# Altium schematic sheet pca20027 - rocketry.SchDoc
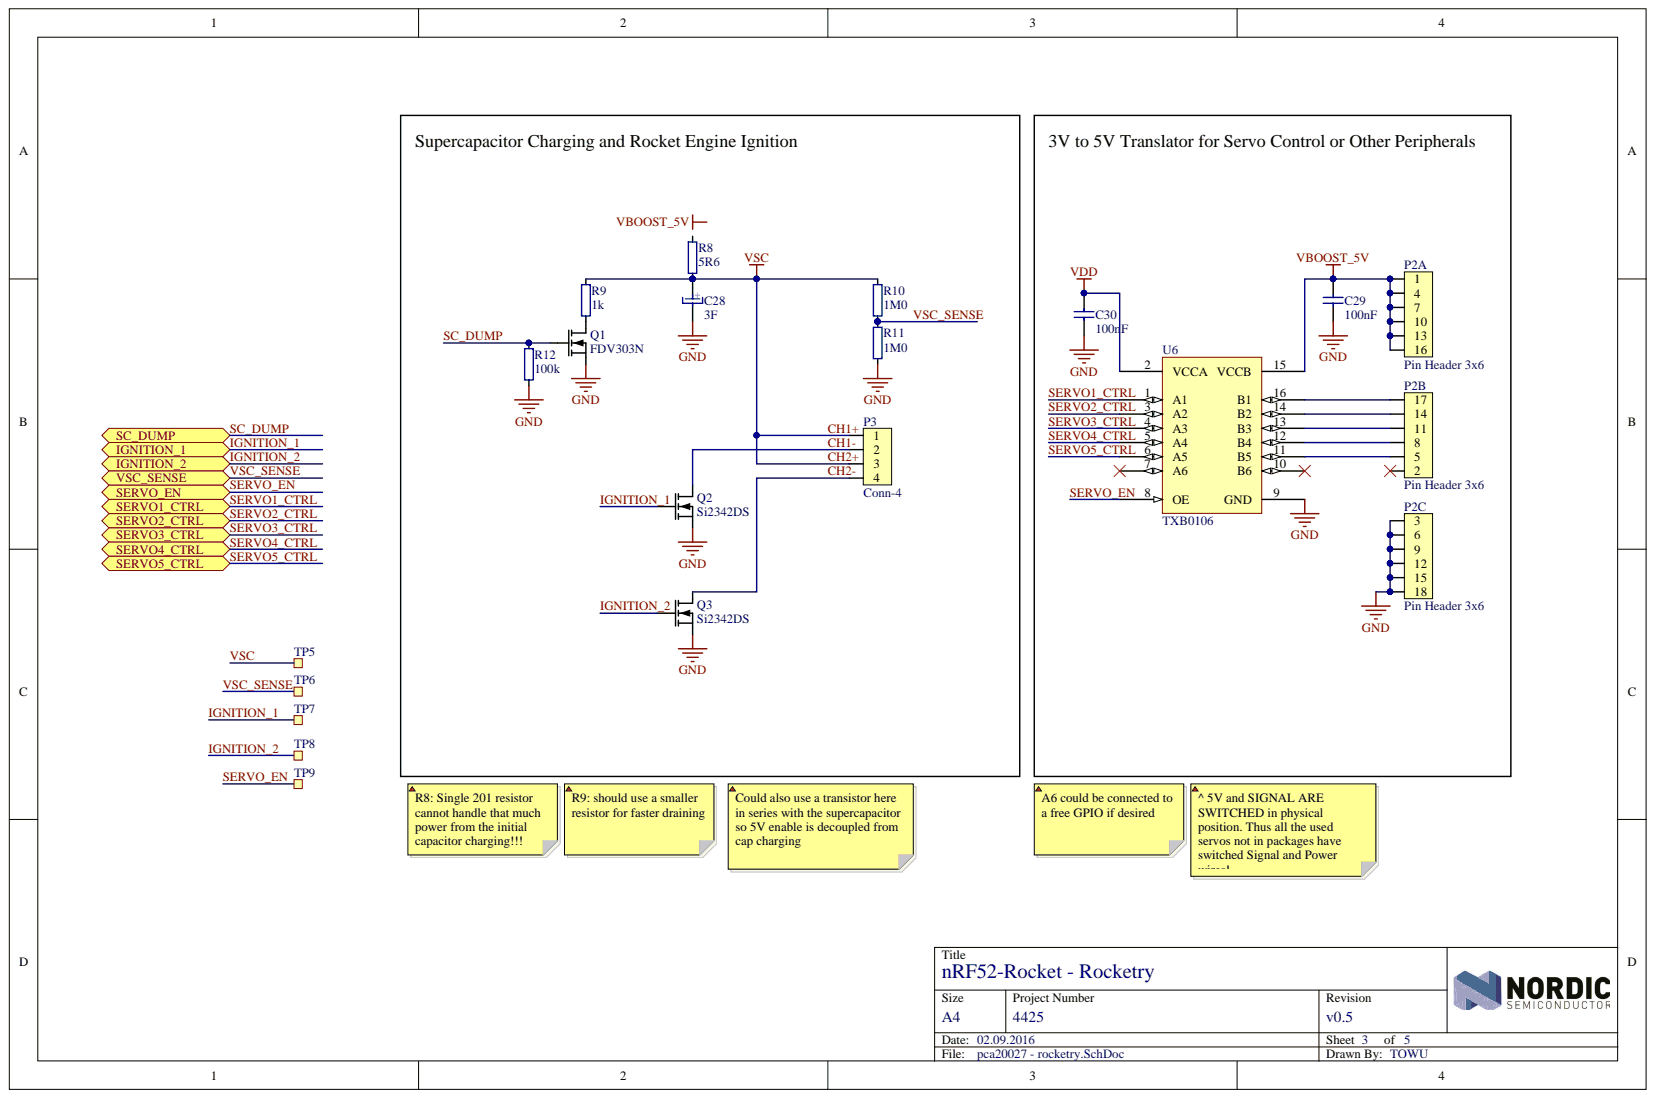
[diagram p0: pca20027 - rocketry.SchDoc]

## Parts
C28  3F  [PM-5R0H305-R]
C29  100nF  [CAPC1608X06L]
C30  100nF  [CAPC1608X06L]
P2  Pin Header 3x6  [HDR_3x6]  (parts A,B,C)
P3  Conn-4  [MPT 0,5/4-2,54]
Q1  FDV303N  [SOT-23a]
Q2  Si2342DS  [SOT-23a]
Q3  Si2342DS  [SOT-23a]
R8  5R6  [RESC1005X04L]
R9  1k  [RESC1608X06L]
R10  1M0  [RESC1608X06L]
R11  1M0  [RESC1608X06L]
R12  100k  [RESC1608X06L]
TP5  Ø1.0mm,SMD  [TP_SMD_Ø1.0mm]  (value not drawn)  (no BOM)
TP6  Ø1.0mm,SMD  [TP_SMD_Ø1.0mm]  (value not drawn)  (no BOM)
TP7  Ø1.0mm,SMD  [TP_SMD_Ø1.0mm]  (value not drawn)  (no BOM)
TP8  Ø1.0mm,SMD  [TP_SMD_Ø1.0mm]  (value not drawn)  (no BOM)
TP9  Ø1.0mm,SMD  [TP_SMD_Ø1.0mm]  (value not drawn)  (no BOM)
U6  TXB0106  [TSOP65P640X110-16N]

## Ports
IGNITION_1, IGNITION_2, SC_DUMP, SERVO1_CTRL, SERVO2_CTRL, SERVO3_CTRL, SERVO4_CTRL, SERVO5_CTRL, SERVO_EN, VSC_SENSE

## Nets
GND: C28.1 C29.1 C30.1 P2.3 P2.6 P2.9 P2.12 P2.15 P2.18 Q1.2 Q2.2 Q3.2 R11.1 R12.1 U6.9(GND)
VBOOST_5V: C29.2 P2.1 P2.4 P2.7 P2.10 P2.13 P2.16 U6.15(VCCB)
VDD: C30.2 U6.2(VCCA)
CH1+: C28.2 P3.1 P3.3 R8.1 R9.2 R10.2 TP5.1
CH1-: P3.2 Q2.3
CH2-: P3.4 Q3.3
IGNITION_1: Q2.1 TP7.1 ; port IGNITION_1
IGNITION_2: Q3.1 TP8.1 ; port IGNITION_2
SC_DUMP: Q1.1 R12.2 ; port SC_DUMP
SERVO1_CTRL: U6.1(A1) ; port SERVO1_CTRL
SERVO2_CTRL: U6.3(A2) ; port SERVO2_CTRL
SERVO3_CTRL: U6.4(A3) ; port SERVO3_CTRL
SERVO4_CTRL: U6.5(A4) ; port SERVO4_CTRL
SERVO5_CTRL: U6.6(A5) ; port SERVO5_CTRL
SERVO_EN: TP9.1 U6.8(OE) ; port SERVO_EN
VSC_SENSE: R10.1 R11.2 TP6.1 ; port VSC_SENSE
NetP2_5: P2.5 U6.11(B5)
NetP2_8: P2.8 U6.12(B4)
NetP2_11: P2.11 U6.13(B3)
NetP2_14: P2.14 U6.14(B2)
NetP2_17: P2.17 U6.16(B1)
NetQ1_3: Q1.3 R9.1

Not connected: P2 2; R8 2; U6 7(A6) 10(B6)

## Library data of the parts
C28 = PM-5R0H305-R: 3F, 5V Supercapacitor (EDLC); Manufacturer: Cooper Industries; Artikkelnr Noca: N.A.; Database Table Name: Capacitors$; Artikkelnr Simpro: N.A.; Component Kind: Standard; Datasheet: http://www.cooperindustries.com/content/dam/public/bussmann/Electronics/Resources/product-datasheets/Bus_Elx_DS_4308_PM_Series.pdf; Footprint Doc: PM-5R0H305-R; LatestRevisionDate: 2016-07-04; Library Name: Nordic Passive DB.DbLib; Manufacturer Part Number: PM-5R0H305-R; Note: 5V; Part Number: PM-5R0H305-R; Pin Count: 2; Published: 2016-07-04; Supplier: Digi-Key; Supplier part number: 283-2858-ND; Value: 3F; Publisher: Nordic Semiconductor
C29 C30 = C0603-100n: Chip Cap 0603; Artikkelnr Noca: N.A.; Database Table Name: Capacitors$; Note: Chip Cap 1%; Artikkelnr Simpro: N.A.; Component Kind: Standard; Footprint Doc: 0603; LatestRevisionDate: 2009-05-08; Library Name: Nordic Passive DB.DbLib; Part Number: C0603-100n; Pin Count: 2; Published: 2009-05-08; Value: 100nF; Publisher: Nordic Semiconductor
P2 = Pin Header 3x6: Pin Header 3x6, 2.54mm (100mil); Artikkelnr Noca: N.A.; Database Table Name: Connectors$; Artikkelnr Simpro: N.A.; Component Kind: Standard; Footprint Doc: HDR_3x6; LatestRevisionDate: 2015-11-18; Library Name: Nordic Passive DB.DbLib; Part Number: Pin Header 3x6; Pin Count: 18; Published: 2015-11-18; Value: Pin Header 3x6; Publisher: Nordic Semiconductor
P3 = MPT 0,5/4-2,54: PHOENIX CONTACT - 1725672 - TERMINAL BLOCK, PCB, 2.54MM, 4WAY; 2nd source part number: 304-1414; Artikkelnr Noca: N.A.; Database Table Name: Connectors$; 2nd source Supplier: Farnell; Artikkelnr Simpro: N.A.; Component Kind: Standard; Datasheet: http://www.farnell.com/datasheets/5117.pdf; Footprint Doc: MPT 0,5/4-2,54; LatestRevisionDate: 2010-03-04; Library Name: Nordic Passive DB.DbLib; Manufacturer: Phoenix; Manufacturer Part Number: 1725672; Note: MPT 0,5/4-2,54; Part Number: MPT 0,5/4-2,54; Pin Count: 2; Published: 2010-03-04; Supplier: Elfa; Supplier part number: 48-354-27; Value: Conn-4; Publisher: Nordic Semiconductor
Q1 = FDV303N: Mosfet FDV303N; 2nd source part number: FDV303NCT-ND; Artikkelnr Noca: 35029048; Artikkelnr Simpro: N.A.; Database Table Name: Semiconductors$; Note: Schottky; 2nd source Supplier: Digi-Key; Component Kind: Standard; Footprint Doc: SOT-23a; LatestRevisionDate: 2009-11-23; Library Name: Nordic Discrete DB.DbLib; Part Number: FDV303N; Pin Count: 3; Published: 2009-05-10; Supplier: Farnell; Supplier part number: 984-5020; Value: FDV303N; Publisher: Nordic Semiconductor
Q2 Q3 = Si2342DS: N-Channel 8V MOSFET; Manufacturer: Vishay Siliconix; Artikkelnr Noca: N.A.; Database Table Name: Semiconductors$; Artikkelnr Simpro: N.A.; Component Kind: Standard; Datasheet: http://www.vishay.com/docs/63302/si2342ds.pdf; Footprint Doc: SOT-23a; LatestRevisionDate: 2016-07-04; Library Name: Nordic Discrete DB.DbLib; Manufacturer Part Number: Si2342DS; Part Number: Si2342DS; Published: 2016-07-04; Supplier: Digi-Key; Supplier part number: SI2342DS-T1-GE3CT-ND; Value: Si2342DS; Publisher: Nordic Semiconductor
R8 = R0402-5R6: Resistor, ±1%, 0.063W; Artikkelnr Noca: 27049019; Artikkelnr Simpro: N.A.; Database Table Name: Resistors$; Note: Chip Res 1%; Value Ohm: 5.6; Component Kind: Standard; Footprint Doc: 0402; LatestRevisionDate: 2012-06-22; Library Name: Nordic Passive DB.DbLib; Part Number: R0402-5R6; Pin Count: 2; Published: 2012-06-22; Value: 5R6; Publisher: Nordic Semiconductor
R9 = R0603-1k0: Resistor, ±1%, 0.06W; Artikkelnr Noca: 27069073; Artikkelnr Simpro: N.A.; Database Table Name: Resistors$; Note: Chip Res 1%; Value Ohm: 1000; Component Kind: Standard; Footprint Doc: 0603; LatestRevisionDate: 2011-08-16; Library Name: Nordic Passive DB.DbLib; Part Number: R0603-1k0; Pin Count: 2; Published: 2009-05-08; Value: 1k; Publisher: Nordic Semiconductor
R10 R11 = R0603-1M0: Resistor, ±1%, 0.06W; Artikkelnr Noca: 27069145; Artikkelnr Simpro: N.A.; Database Table Name: Resistors$; Note: Chip Res 1%; Value Ohm: 1000000; Component Kind: Standard; Footprint Doc: 0603; LatestRevisionDate: 2011-08-16; Library Name: Nordic Passive DB.DbLib; Part Number: R0603-1M0; Pin Count: 2; Published: 2009-05-08; Value: 1M0; Publisher: Nordic Semiconductor
R12 = R0603-100k: Resistor, ±1%, 0.06W; Artikkelnr Noca: 27069121; Artikkelnr Simpro: N.A.; Database Table Name: Resistors$; Note: Chip Res 1%; Value Ohm: 100000; Component Kind: Standard; Footprint Doc: 0603; LatestRevisionDate: 2011-08-16; Library Name: Nordic Passive DB.DbLib; Part Number: R0603-100k; Pin Count: 2; Published: 2009-05-08; Value: 100k; Publisher: Nordic Semiconductor
TP5-TP9 = TP_SMD_Ø1.0MM: 1.0mm circular SMD testpad; Manufacturer: Nordic Semiconductor; Artikkelnr Noca: N.A.; Database Table Name: Connectors$; Artikkelnr Simpro: N.A.; Footprint Doc: TP_SMD_Ø1.0mm; LatestRevisionDate: 2013-06-11; Library Name: Nordic Passive DB.DbLib; Part Number: TP_SMD_Ø1.0MM; Pin Count: 1; Published: 2013-06-11; Value: Ø1.0mm,SMD; Publisher: Nordic Semiconductor
U6 = TXB0106PWR: 6-bit bidirectional voltage-level translator with auto-direction sensing; 2nd source part number: 296-23759-1-ND; Manufacturer: Texas Instruments; Artikkelnr Noca: N.A.; Database Table Name: Misc IC$; Note: Translator, Bidirectional; 2nd source Supplier: Digi-Key; Artikkelnr Simpro: N.A.; Component Kind: Standard; Datasheet: http://focus.ti.com/lit/ds/symlink/txb0106.pdf; Footprint Doc: TSSOP-16; LatestRevisionDate: 2013-10-29; Library Name: Nordic Active DB.DbLib; Manufacturer Part Number: TXB0106PWR; Part Number: TXB0106PWR; Pin Count: 16; Published: 2011-06-06; Supplier: Farnell; Supplier part number: 169-4092; Value: TXB0106; Publisher: Nordic Semiconductor
